# Altium schematic sheet 09 - FPGA POWER.SchDoc
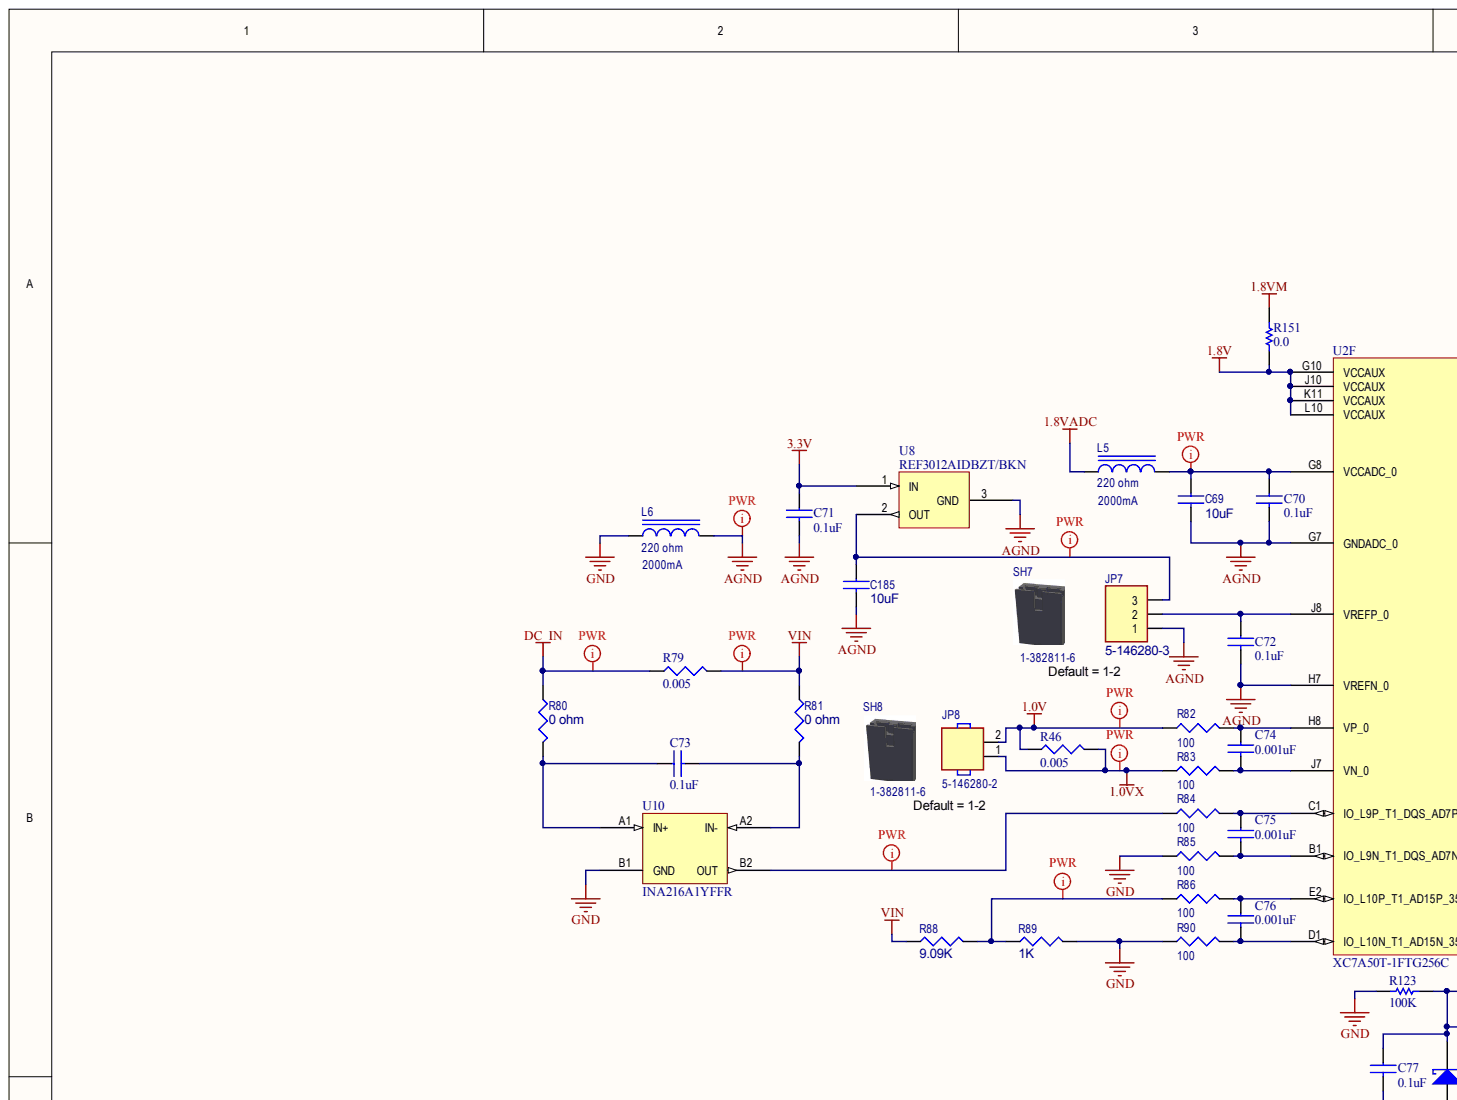
[diagram p0: 09 - FPGA POWER.SchDoc, top left]
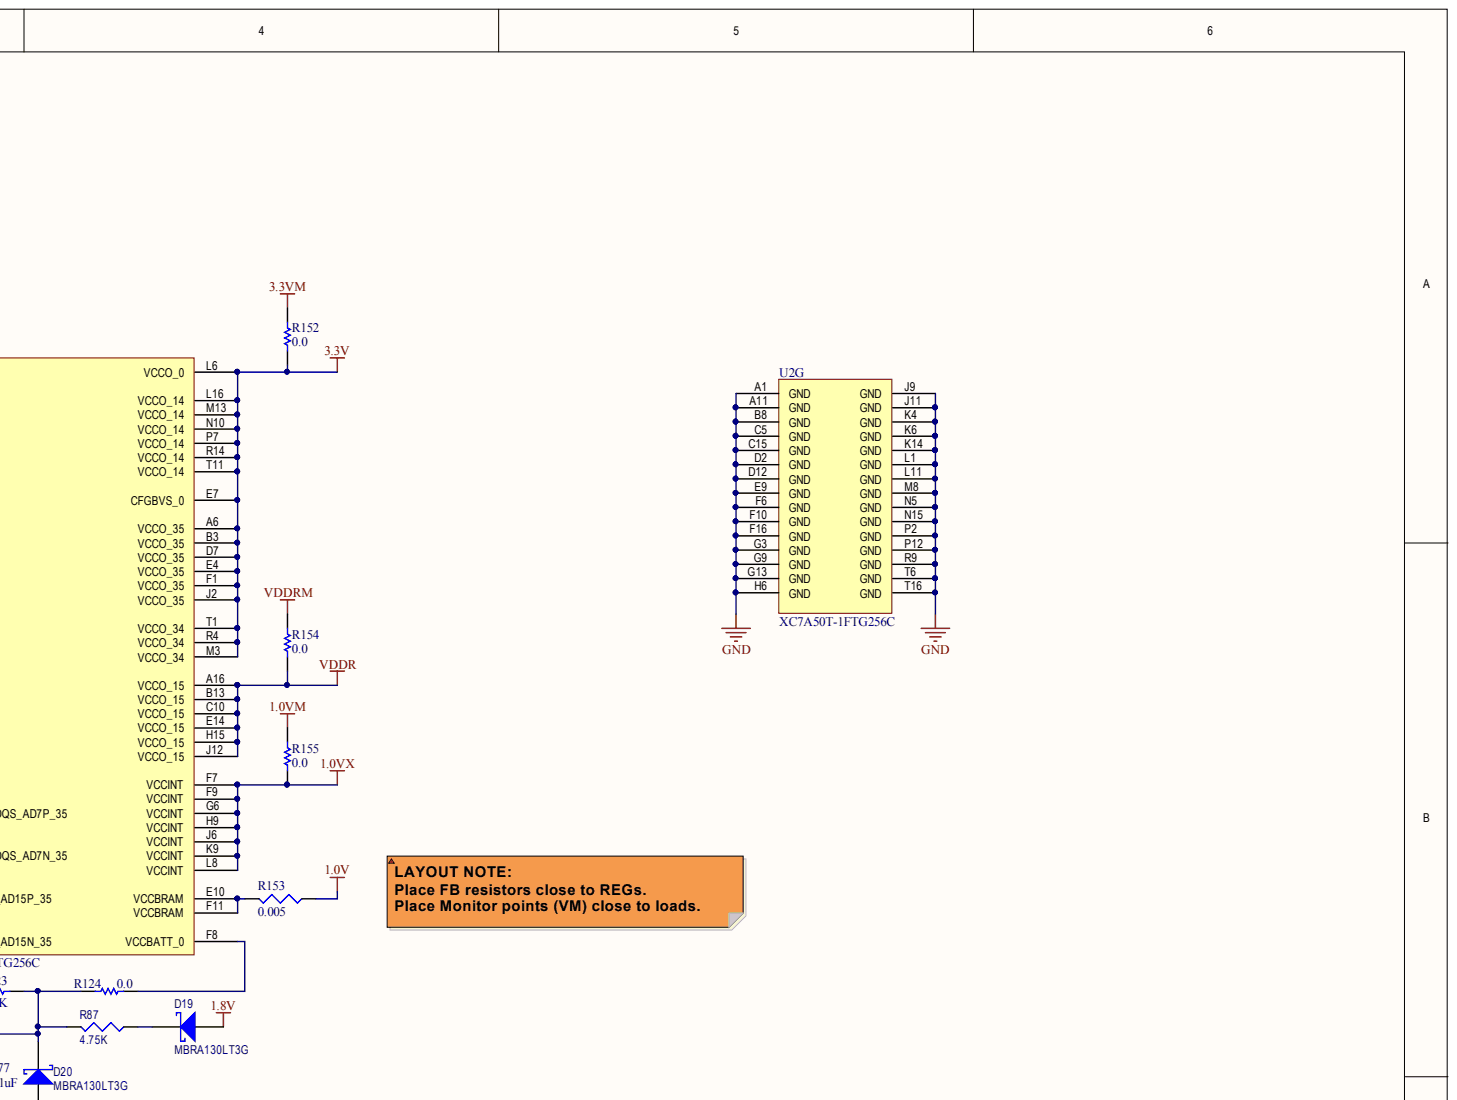
[diagram p1: 09 - FPGA POWER.SchDoc, top right]
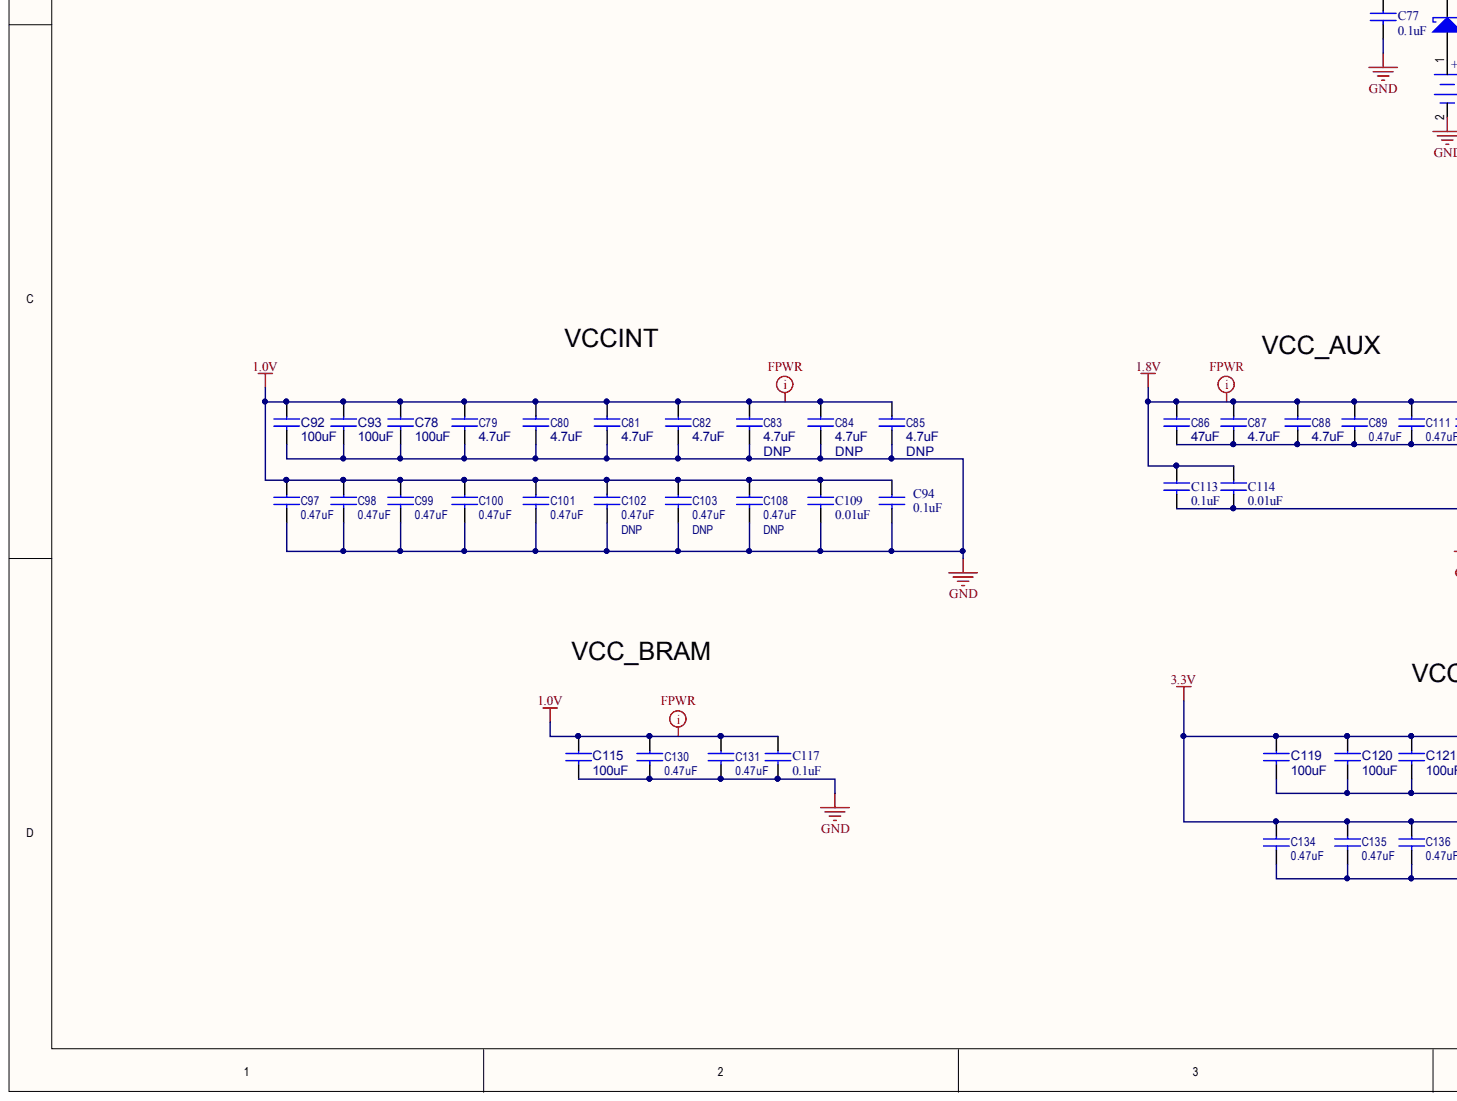
[diagram p2: 09 - FPGA POWER.SchDoc, bottom left]
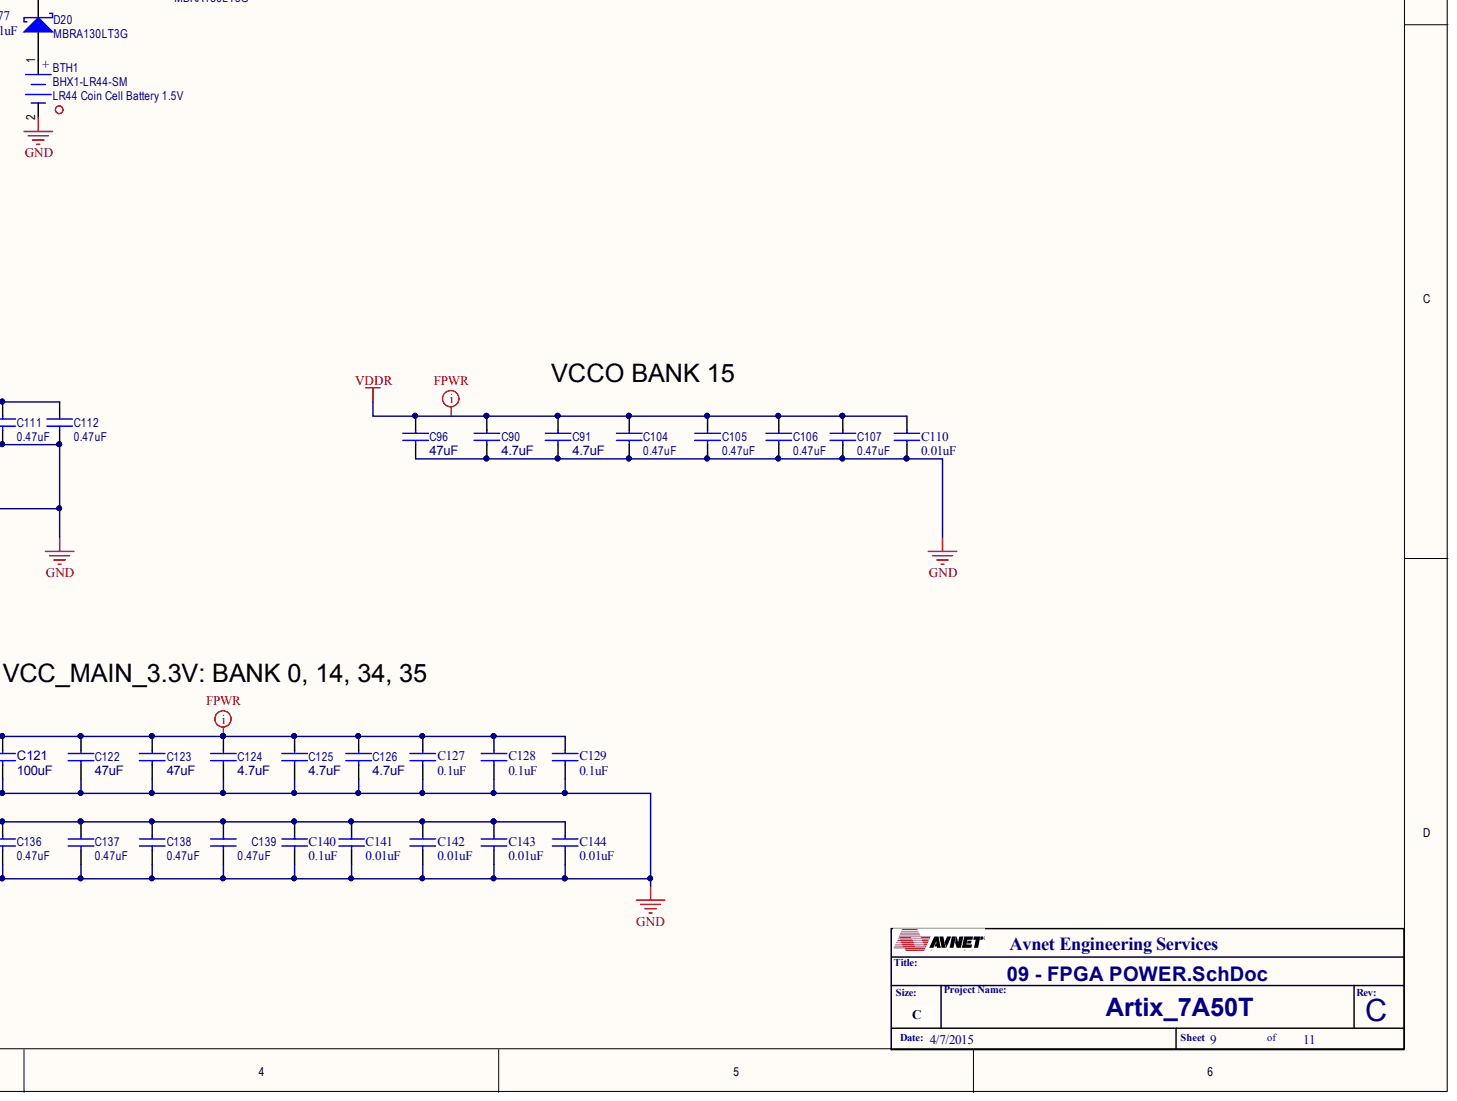
[diagram p3: 09 - FPGA POWER.SchDoc, bottom right]

## Parts
BPH1  LR44 Coin Cell Battery 1.5V  (mechanical)
BTH1  BHX1-LR44-SM
C69  10uF  [C0603]
C70  value 0.1uF  [C0201]  (hidden comment: PC522)
C71  value 0.1uF  [C0201]  (hidden comment: PC522)
C72  value 0.1uF  [C0201]  (hidden comment: PC522)
C73  value 0.1uF  [C0201]  (hidden comment: PC522)
C74  value 0.001uF  [C0201]  (hidden comment: 0.001uF 6.3V 0201)
C75  value 0.001uF  [C0201]  (hidden comment: 0.001uF 6.3V 0201)
C76  value 0.001uF  [C0201]  (hidden comment: 0.001uF 6.3V 0201)
C77  value 0.1uF  [C0201]  (hidden comment: PC522)
C78  100uF  [C1210]
C79  value 4.7uF  [C0402]  (hidden comment: 4.8uF)
C80  value 4.7uF  [C0402]  (hidden comment: 4.8uF)
C81  value 4.7uF  [C0402]  (hidden comment: 4.8uF)
C82  value 4.7uF  [C0402]  (hidden comment: 4.8uF)
C83  value 4.7uF  [C0402]  (hidden comment: 4.8uF)
C84  value 4.7uF  [C0402]  (hidden comment: 4.8uF)
C85  value 4.7uF  [C0402]  (hidden comment: 4.8uF)
C86  47uF  [C0805]
C87  value 4.7uF  [C0402]  (hidden comment: 4.8uF)
C88  value 4.7uF  [C0402]  (hidden comment: 4.8uF)
C89  value 0.47uF  [C0402]  (hidden comment: PC475)
C90  value 4.7uF  [C0402]  (hidden comment: 4.8uF)
C91  value 4.7uF  [C0402]  (hidden comment: 4.8uF)
C92  100uF  [C1210]
C93  100uF  [C1210]
C94  value 0.1uF  [C0201]  (hidden comment: PC522)
C96  47uF  [C0805]
C97  value 0.47uF  [C0402]  (hidden comment: PC475)
C98  value 0.47uF  [C0402]  (hidden comment: PC475)
C99  value 0.47uF  [C0402]  (hidden comment: PC475)
C100  value 0.47uF  [C0402]  (hidden comment: PC475)
C101  value 0.47uF  [C0402]  (hidden comment: PC475)
C102  value 0.47uF  [C0402]  (hidden comment: PC475)
C103  value 0.47uF  [C0402]  (hidden comment: PC475)
C104  value 0.47uF  [C0402]  (hidden comment: PC475)
C105  value 0.47uF  [C0402]  (hidden comment: PC475)
C106  value 0.47uF  [C0402]  (hidden comment: PC475)
C107  value 0.47uF  [C0402]  (hidden comment: PC475)
C108  value 0.47uF  [C0402]  (hidden comment: PC475)
C109  value 0.01uF  [C0201]  (hidden comment: PC003)
C110  value 0.01uF  [C0201]  (hidden comment: PC003)
C111  value 0.47uF  [C0402]  (hidden comment: PC475)
C112  value 0.47uF  [C0402]  (hidden comment: PC475)
C113  value 0.1uF  [C0201]  (hidden comment: PC522)
C114  value 0.01uF  [C0201]  (hidden comment: PC003)
C115  100uF  [C1210]
C117  value 0.1uF  [C0201]  (hidden comment: PC522)
C119  100uF  [C1210]
C120  100uF  [C1210]
C121  100uF  [C1210]
C122  47uF  [C0805]
C123  47uF  [C0805]
C124  value 4.7uF  [C0402]  (hidden comment: 4.8uF)
C125  value 4.7uF  [C0402]  (hidden comment: 4.8uF)
C126  value 4.7uF  [C0402]  (hidden comment: 4.8uF)
C127  value 0.1uF  [C0201]  (hidden comment: PC522)
C128  value 0.1uF  [C0201]  (hidden comment: PC522)
C129  value 0.1uF  [C0201]  (hidden comment: PC522)
C130  value 0.47uF  [C0402]  (hidden comment: PC475)
C131  value 0.47uF  [C0402]  (hidden comment: PC475)
C134  value 0.47uF  [C0402]  (hidden comment: PC475)
C135  value 0.47uF  [C0402]  (hidden comment: PC475)
C136  value 0.47uF  [C0402]  (hidden comment: PC475)
C137  value 0.47uF  [C0402]  (hidden comment: PC475)
C138  value 0.47uF  [C0402]  (hidden comment: PC475)
C139  value 0.47uF  [C0402]  (hidden comment: PC475)
C140  value 0.1uF  [C0201]  (hidden comment: PC522)
C141  value 0.01uF  [C0201]  (hidden comment: PC003)
C142  value 0.01uF  [C0201]  (hidden comment: PC003)
C143  value 0.01uF  [C0201]  (hidden comment: PC003)
C144  value 0.01uF  [C0201]  (hidden comment: PC003)
C185  10uF  [C0603]
D19  Device: MBRA130LT3G  [SMA]  (hidden comment: DD004)
D20  Device: MBRA130LT3G  [SMA]  (hidden comment: DD004)
JP7  Device: 5-146280-3  [HDR3-100]  (hidden comment: EH003)
JP8  5-146280-2  [HDR2-100]
L5  value 220 ohm  Max I: 2000mA  [L0805]  (hidden comment: PF009)
L6  value 220 ohm  Max I: 2000mA  [L0805]  (hidden comment: PF009)
R46  value 0.005  [RESC1206(3216)_L]  (hidden comment: RES 1206 0.005)
R79  value 0.005  [RESC1206(3216)_L]  (hidden comment: RES 1206 0.005)
R80  0 ohm  [R0402]
R81  0 ohm  [R0402]
R82  value 100  [R0402]  (hidden comment: 0402)
R83  value 100  [R0402]  (hidden comment: 0402)
R84  value 100  [R0402]  (hidden comment: 0402)
R85  value 100  [R0402]  (hidden comment: 0402)
R86  value 100  [R0402]  (hidden comment: 0402)
R87  value 4.75K  [R0402]  (hidden comment: 0402)
R88  9.09K  [R0402]
R89  1K  [R0402]
R90  value 100  [R0402]  (hidden comment: 0402)
R123  100K  [RESC0402(1005)_N]
R124  0.0  [RESC0402(1005)_N]
R151  0.0  [RESC0201(0603)_N]
R152  0.0  [RESC0201(0603)_N]
R153  value 0.005  [RESC1206(3216)_L]  (hidden comment: RES 1206 0.005)
R154  0.0  [RESC0201(0603)_N]
R155  0.0  [RESC0201(0603)_N]
SH7  1-382811-6  (mechanical)
SH8  1-382811-6  (mechanical)
U2  MFGPN: XC7A50T-1FTG256C  [FTG256]  (hidden comment: XNLX-XC7A50T-XNLX-FTG256)  (parts F,G)
U8  MFGPN: REF3012AIDBZT/BKN  [SOT23]  (hidden comment: TI REF3012)
U10  MFGPN: INA216A1YFFR  [WCSP4]  (hidden comment: TI INA216A1)

## Nets
1.0V: C78.1 C79.1 C80.1 C81.1 C82.1 C83.1 C84.1 C85.1 C92.1 C93.1 C94.1 C97.1 C98.1 C99.1 C100.1 C101.1 C102.1 C103.1 C108.1 C109.1 C115.1 C117.1 C130.1 C131.1 JP8.2 R46.1 R82.1 R153.1
1.0VM: R155.2
1.0VX: JP8.1 R46.2 R83.1 R155.1 U2.F7(VCCINT) U2.F9(VCCINT) U2.G6(VCCINT) U2.H9(VCCINT) U2.J6(VCCINT) U2.K9(VCCINT) U2.L8(VCCINT)
1.8V: C86.1 C87.1 C88.1 C89.1 C111.1 C112.1 C113.1 C114.1 D19.A R151.1 U2.G10(VCCAUX) U2.J10(VCCAUX) U2.K11(VCCAUX) U2.L10(VCCAUX)
1.8VADC: L5.1
1.8VM: R151.2
3.3V: C71.1 C119.1 C120.1 C121.1 C122.1 C123.1 C124.1 C125.1 C126.1 C127.1 C128.1 C129.1 C134.1 C135.1 C136.1 C137.1 C138.1 C139.1 C140.1 C141.1 C142.1 C143.1 C144.1 R152.1 U2.A6(VCCO_35) U2.B3(VCCO_35) U2.D7(VCCO_35) U2.E4(VCCO_35) U2.E7(CFGBVS_0) U2.F1(VCCO_35) U2.J2(VCCO_35) U2.L6(VCCO_0) U2.L16(VCCO_14) U2.M3(VCCO_34) U2.M13(VCCO_14) U2.N10(VCCO_14) U2.P7(VCCO_14) U2.R4(VCCO_34) U2.R14(VCCO_14) U2.T1(VCCO_34) U2.T11(VCCO_14) U8.1
3.3VM: R152.2
AGND: C69.2 C70.2 C71.2 C72.2 C185.2 JP7.1 L6.2 U2.G7(GNDADC_0) U2.H7(VREFN_0) U8.3
DC_IN: R79.1 R80.1
GND: BTH1.2 C77.2 C78.2 C79.2 C80.2 C81.2 C82.2 C83.2 C84.2 C85.2 C86.2 C87.2 C88.2 C89.2 C90.2 C91.2 C92.2 C93.2 C94.2 C96.2 C97.2 C98.2 C99.2 C100.2 C101.2 C102.2 C103.2 C104.2 C105.2 C106.2 C107.2 C108.2 C109.2 C110.2 C111.2 C112.2 C113.2 C114.2 C115.2 C117.2 C119.2 C120.2 C121.2 C122.2 C123.2 C124.2 C125.2 C126.2 C127.2 C128.2 C129.2 C130.2 C131.2 C134.2 C135.2 C136.2 C137.2 C138.2 C139.2 C140.2 C141.2 C142.2 C143.2 C144.2 L6.1 R85.1 R89.1 R90.1 R123.1 U2.A1(GND) U2.A11(GND) U2.B8(GND) U2.C5(GND) U2.C15(GND) U2.D2(GND) U2.D12(GND) U2.E9(GND) U2.F6(GND) U2.F10(GND) U2.F16(GND) U2.G3(GND) U2.G9(GND) U2.G13(GND) U2.H6(GND) U2.J9(GND) U2.J11(GND) U2.K4(GND) U2.K6(GND) U2.K14(GND) U2.L1(GND) U2.L11(GND) U2.M8(GND) U2.N5(GND) U2.N15(GND) U2.P2(GND) U2.P12(GND) U2.R9(GND) U2.T6(GND) U2.T16(GND) U10.B1(GND)
VDDR: C90.1 C91.1 C96.1 C104.1 C105.1 C106.1 C107.1 C110.1 R154.1 U2.A16(VCCO_15) U2.B13(VCCO_15) U2.C10(VCCO_15) U2.E14(VCCO_15) U2.H15(VCCO_15) U2.J12(VCCO_15)
VDDRM: R154.2
VIN: R79.2 R81.1 R88.1
NetBTH1_1: BTH1.1 D20.A
NetC69_1: C69.1 C70.1 L5.2 U2.G8(VCCADC_0)
NetC72_1: C72.1 JP7.2 U2.J8(VREFP_0)
NetC73_1: C73.1 R80.2 U10.A1(IN+)
NetC73_2: C73.2 R81.2 U10.A2(IN-)
NetC74_1: C74.1 R82.2 U2.H8(VP_0)
NetC74_2: C74.2 R83.2 U2.J7(VN_0)
NetC75_1: C75.1 R84.2 U2.C1(IO_L9P_T1_DQS_AD7P_35)
NetC75_2: C75.2 R85.2 U2.B1(IO_L9N_T1_DQS_AD7N_35)
NetC76_1: C76.1 R86.2 U2.E2(IO_L10P_T1_AD15P_35)
NetC76_2: C76.2 R90.2 U2.D1(IO_L10N_T1_AD15N_35)
NetC77_1: C77.1 D20.C R87.2 R123.2 R124.1
NetC185_1: C185.1 JP7.3 U8.2
NetD19_C: D19.C R87.1
NetR84_1: R84.1 U10.B2(OUT)
NetR86_1: R86.1 R88.2 R89.2
NetR124_2: R124.2 U2.F8(VCCBATT_0)
NetR153_2: R153.2 U2.E10(VCCBRAM) U2.F11(VCCBRAM)

## Library data of the parts
BPH1 = DUMMY_0: LR44 Coin Cell Battery 1.8V; MFG Code: ANY; MFGPN: ANY; Library Name: ZYNQ_Z7045_MITX_REVA.SCHLIB
BTH1 = BATT: Conn Battery SKT 2 POS 2.54mm Solder RA Thru-Hole 3 Terminal 1 Port Tray; Battery Type: Alkaline LR44 or SR44 button cell - 1.5V; MFG Code: TYC; Component Kind: Standard; MFGPN: BHX1-LR44-SM; Note: Conn Battery SKT 2 POS 2.54mm Solder RA Thru-Hole 3 Terminal 1 Port Tray; Library Name: ZYNQ_Z7045_MITX_REVA.SCHLIB
C69 C185 = AVPC-0000086: CAP SM 10uF 6.3V 20% X5R C0603; PartCode: PC; AsyTxt: PC086; Database Table Name: Passive-Cap$; MFG Code: AVX; MFGPN: 06036D106MAT2A; AVLNo: AVPC-0000086; CLASSNAME: ETH_PWR; Component Kind: Standard; ComponentLink1Description: Datasheet; Device: CAP; Dielectric: X5R; ESR: CAP; PkgType: SM; RoHS: Yes; Status: Preliminary; Tol: 20%; Value: 10uF; Volt: 6.3V; ComponentLink1URL: C:\AVDBLIB\DATASHEETS\AVX_CCOG.pdf; Library Name: Avnet_DBlib.DbLib
C70-C73 C77 C94 C113 C117 C127-C129 C140 = AVPC-0000522: CAP SM 0.1uF 10V 10% X5R C0201; PartCode: PC; Database Table Name: Passive-Cap$; MFG Code: AVX; MFGPN: 02016D104KAT2A; AsyTxt: PC522; AVLNo: AVPC-0000522; Component Kind: Standard; ComponentLink1Description: Datasheet; Device: CAP; Dielectric: X5R; PkgType: SM; RoHS: Yes; Status: Preliminary; Tol: 10%; Volt: 10V; ComponentLink1URL: C:\AVDBLIB\DATASHEETS\AVX_CCOG.pdf; Library Name: Avnet_DBlib.DbLib
C74-C76 = CAPC 0.001uF 6.3V 0201: Ceramic Chip Capacitor 0201 0.001uF 6.3v 10% X7R; AES Part #: AVPC-0000091; Preferred Part #: PIC ECJ-ZEB0J102K; MFGPN: GRM033R71E102KA01D; Component Kind: Standard; Library Name: Passive.SchLib; Signal Integrity: CAP; Simulation: CAP; Status: Preliminary
C78 C92 C93 C115 C119-C121 = AVPC-0000209: CAP SM 100uF 6.3V 20% X5R C1206; PartCode: PC; AsyTxt: PC209; Database Table Name: Passive-Cap$; MFG Code: MUR; MFGPN: GRM32EF50J107ZE20K; Power: 0; Availability: 180K-AV; AVLNo: AVPC-0000209; Component Kind: Standard; ComponentLink1Description: Datasheet; Cost: .41; Device: CAP; Dielectric: X5R; PkgType: SM; Received: 0; RoHS: Yes; Size: 9.11; Status: Preliminary; Tol: 20%; Value: 100uF; Volt: 6.3V; ComponentLink1URL: C:\AVDBLIB\DATASHEETS\GRM31CR60J107ME39.pdf; Library Name: Avnet_DBlib.DbLib
C79-C85 C87 C88 C90 C91 C124-C126 = AVPC-0000499: CAP SM 4.7uF 6.3V 20% X5R C0402 85C; PartCode: PC; AsyTxt: PC499; Database Table Name: Passive-Cap$; MFG Code: AVX; MFGPN: GRM155R60J475ME87D; Power: 0; Availability: 140K-DK; AVLNo: AVPC-0000499; Component Kind: Standard; ComponentLink1Description: Datasheet; Cost: .46; Device: CAP; Dielectric: X5R; PkgType: SM; Received: 0; RoHS: Yes; Size: 1.9; Status: Preliminary; Tol: 20%; Volt: 6.3V; ComponentLink1URL: C:\AVDBLIB\DATASHEETS\murata_caps_c02e.pdf; Library Name: Avnet_DBlib.DbLib
  C83-C85: DNP: DNP
C86 C96 C122 C123 = AVPC-0000521: CAP SM 47uF 6.3V 20% X5R C0805; PartCode: PC; AsyTxt: PC521; Database Table Name: Passive-Cap$; MFG Code: TYC; MFGPN: JMK212BJ476MG-T; Power: 0; Availability: 100K-AV; AVLNo: AVPC-0000521; Component Kind: Standard; ComponentLink1Description: Datasheet; Cost: .18; Device: CAP; Dielectric: X5R; PkgType: SM; Received: 0; RoHS: Yes; Size: 5.42; Status: Preliminary; Tol: 20%; Value: 47uF; Volt: 6.3V; ComponentLink1URL: C:\AVDBLIB\DATASHEETS\TYC_Caps.pdf; Library Name: Avnet_DBlib.DbLib
C89 C97-C108 C111 C112 C130 C131 C134-C139 = AVPC-0000475: CAP SM 0.47uF 6.3V 10% X5R C0402; PartCode: PC; AVLNo: AVPC-0000529; Database Table Name: Passive-Cap$; MFG Code: TAI; MFGPN: JMK105BJ474KV-F/BKN; AsyTxt: PC475; Component Kind: Standard; ComponentLink1Description: Datasheet; ComponentLink2Description: MFR Website; Device: CAP; Dielectric: X5R; ESR: CAP; PkgType: SM; RoHS: Yes; Status: Preliminary; Tol: 10%; Volt: 6.3V; ComponentLink1URL: C:\AVDBLIB\DATASHEETS\; Library Name: Avnet_DBlib.DbLib
  C102 C103 C108: DNP: DNP
C109 C110 C114 C141-C144 = AVPC-0000003: CAP SM 0.01uF 10V 10% X5R C0201; PartCode: PC; Database Table Name: Passive-Cap$; MFG Code: AVX; MFGPN: 0201ZD103KAT2A; AsyTxt: PC003; AVLNo: AVPC-0000003; Component Kind: Standard; ComponentLink1Description: Datasheet; ComponentLink2Description: MFR Website; ComponentLink2URL: www.avx.com; Device: CAP; Dielectric: X5R; ESR: CAP; PkgType: SM; RoHS: Yes; Status: Preliminary; Tol: 10%; Volt: 10V; ComponentLink1URL: C:\AVDBLIB\DATASHEETS\AVX_CX5R.pdf; Library Name: Avnet_DBlib.DbLib
D19 D20 = AVDD-0000004: Diode SM MBRA130LT3G Schottky 1.0A 30V    DIO-S SMA; PartCode: DD; Database Table Name: Discrete-Diode$; MFG Code: MOT; AsyTxt: DD004; AVLNo: AVDD-0000004; Component Kind: Standard; ComponentLink1Description: Datasheet; Device Sub Type: MBRA130LT3G; Device Type: Diode; Imax: 1.0A; MFGPN: MBRA130LT3G; PkgType: SM; RoHS: Yes; Status: Preliminary; Technology: Schottky; Vr: 30V; ComponentLink1URL: C:\AVDBLIB\DATASHEETS\MBRA130LT3G.PDF; Library Name: Avnet_DBlib.DbLib
JP7 = AVEH-0000003: Header TH 100mil 3x1  HDR3 HDR3-100; PartCode: EH; Database Table Name: EM-Header$; MFG Code: AMP; AsyTxt: EH003; AVLNo: AVEH-0000003; Col-Row: 3x1; Component Kind: Standard; ComponentLink1Description: Datasheet; Device Type: Header; MFGPN: 5-146280-3; Pitch: 100mil; PkgType: TH; RoHS: Yes; Status: Preliminary; ComponentLink1URL: C:\AVDBLIB\DATASHEETS\146280.pdf; Library Name: Avnet_DBlib.DbLib
JP8 = HDR2: CONN HEADR BRKWAY .100 2POS STR; MFG Code: AMP; Component Kind: Standard; MFGPN: 5-146280-2; Note: CONN HEADR BRKWAY .100 2POS STR; Library Name: ZYNQ_Z7045_MITX_REVA.SCHLIB
L5 L6 = AVPF-0000009: FB SM 220 ohm@100MHz 2000mA 0.25 0.045 ohm@DC L0805; PartCode: PF; Database Table Name: Passive-Ferrite Bead$; MFG Code: MUR; MFGPN: BLM21PG221SN1D; Test Freq: 100MHz; Tol: 0.25; AsyTxt: PF009; AVLNo: AVPF-0000009; Component Kind: Standard; ComponentLink1Description: Datasheet; DCR: 0.045 ohm; Device: FB; PkgType: SM; RoHS: Yes; Status: Preliminary; ComponentLink1URL: C:\AVDBLIB\DATASHEETS\L0110S0100BLM21P.pdf; Library Name: Avnet_DBlib.DbLib
R46 R79 R153 = RES 1206 0.005: RES 0.005, 1%, 1W, 50ppm 1206; MFG: STACKPOLE; MFGPN: CSNL1206FT5L00; PKG: 1206; Component Kind: Standard; Library Name: Artix_7A50_B.SCHLIB; TOL: 1%
R80 R81 = AVPR-0000027: RES SM 0 ohm 62mW J R0402; PartCode: PR; AsyTxt: PR027; Database Table Name: Passive-Res$; MFG Code: DAL; MFGPN: CRCW04020000Z0ED; AVLNo: AVPR-0000027; Component Kind: Standard; ComponentLink1Description: Datasheet; Device: RES; PkgType: SM; RoHS: Yes; Status: Preliminary; Value: 0 ohm; Watt: 62mW; ComponentLink1URL: C:\AVDBLIB\DATASHEETS\Dale_CRCW.pd; Library Name: Avnet_DBlib.DbLib
R82-R86 R90 = AVPR-0000030: 100 ohm 1% 0402; Database Table Name: Passive-Res$; MFG Code: DAL; MFGPN: CRCW0402100RFKED; Component Kind: Standard; Library Name: Avnet_DBlib.DbLib
R87 = AVPR-0000092: Res Thick Film 0402 4.75K Ohm 1% 1/16W ±100ppm/°C Molded SMD SMD Paper T/R; Database Table Name: Passive-Res$; MFG Code: DAL; MFGPN: CRCW04024K75FKED; Component Kind: Standard; Note: Res Thick Film 0402 4.75K Ohm 1% 1/16W ±100ppm/°C Molded SMD SMD Paper T/R; Library Name: Avnet_DBlib.DbLib
R88 = AVPR-0000094: RES SM 9.09K 62mW 0.01 R0402; PartCode: PR; AsyTxt: PR094; AVLNo: AVPR-0000xxx; Database Table Name: Passive-Res$; MFG Code: DAL; MFGPN: CRCW04029K09FKED; Tol: 0.01; Component Kind: Standard; ComponentLink1Description: Datasheet; Device: RES; PkgType: SM; RoHS: Yes; Status: Preliminary; Value: 9.09K; Watt: 62mW; ComponentLink1URL: C:\AVDBLIB\DATASHEETS\Dale_CRCW.pd; Library Name: Avnet_DBlib.DbLib
R89 = AVPR-0000052: RES SM 1K 62mW 0.01 R0402; PartCode: PR; AsyTxt: PR052; Database Table Name: Passive-Res$; MFG Code: DAL; MFGPN: CRCW04021K00FKED; Tol: 0.01; AVLNo: AVPR-0000052; Component Kind: Standard; ComponentLink1Description: Datasheet; Device: RES; PkgType: SM; RoHS: Yes; Status: Preliminary; Value: 1K; Watt: 62mW; ComponentLink1URL: C:\AVDBLIB\DATASHEETS\Dale_CRCW.pd; Library Name: Avnet_DBlib.DbLib
R123 = AVPR-0001060-A.00: RES 100K OHM 0402 1% 1/16W; Mount Type (SM/TH): SM; Numeric Value (Ω): 100000; Preferrence Code: A; Rating: 0.063W; Component Kind: Standard; Component Type: RES; Material: Thick Film; Package: 0402 (1005 Metric); RoHS: Y; Tolerance: 1%; Value: 100K; Library Name: AES ALTIUM VAULT
R124 = AVPR-0000579-A.00: RES 0.0 OHM JUMP 0402 1/16W; Mount Type (SM/TH): SM; Preferrence Code: A; Rating: 0.063W; Component Kind: Standard; Component Type: RES; Material: Thick Film; Numeric Value (Ω): 0.0; Package: 0402 (1005 Metric); RoHS: Y; Tolerance: -; Value: 0.0; Library Name: AES ALTIUM VAULT
R151 R152 R154 R155 = AVPR-0000001-A.00: RES 0.0 OHM JUMP 0201 1/20W; Mount Type (SM/TH): SM; Preferrence Code: A; Rating: 0.05W; Component Kind: Standard; Component Type: RES; Material: Thick Film; Numeric Value (Ω): 0.0; Package: 0201 (0603 Metric); RoHS: Y; Tolerance: -; Value: 0.0; Library Name: AES ALTIUM VAULT
SH7 SH8 = AVEH-0000115: SHUNT,ECON,PHBR 15AU,BLACK L/P; MFG Code: AMP; MFGPN: 1-382811-6; Note: SHUNT,ECON,PHBR 15AU,BLACK L/P; Library Name: ZYNQ_Z7045_MITX_REVA.SCHLIB
U2 = XNLX-XC7A50T-XNLX-FTG256: PKG: FBGA256; Component Kind: Standard; Library Name: Artix_7A50_1.SCHLIB
U8 = TI REF3012: TI REF3012 1.25V CMOS Vref IC; PKG: SOT23-3; Component Kind: Standard; Library Name: Artix_7A50.SCHLIB
U10 = TI INA216A1: TI Current Shunt Monitor, high side, Gain: 25; PKG: WCSP4 BGA; Component Kind: Standard; Library Name: Artix_7A50.SCHLIB
